# Altium schematic sheet MCU.SchDoc
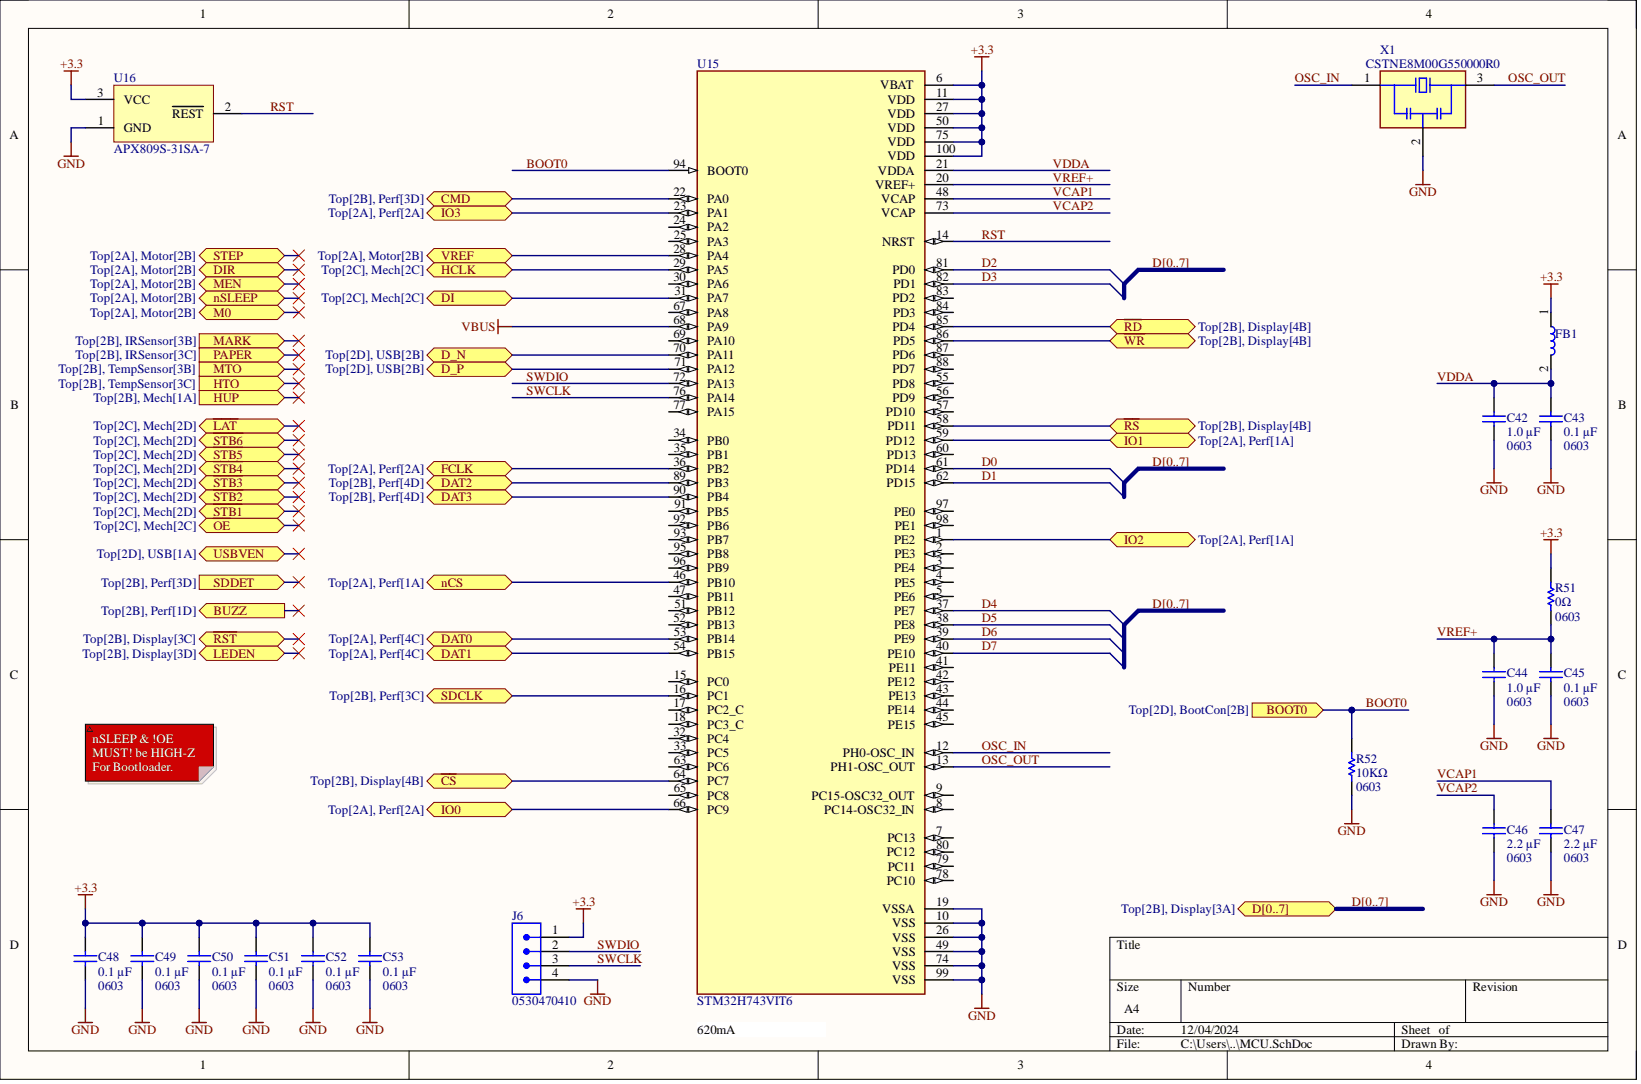
[diagram p0: MCU.SchDoc]

## Parts
C42  value 1.0 µF  [0603]  (hidden comment: GRM188D71H105KE01J)
C43  value 0.1 µF  [0603]  (hidden comment: GRM188R72A104MA35J)
C44  value 1.0 µF  [0603]  (hidden comment: GRM188D71H105KE01J)
C45  value 0.1 µF  [0603]  (hidden comment: GRM188R72A104MA35J)
C46  value 2.2 µF  [0603]  (hidden comment: GRM188R61E225KA12J)
C47  value 2.2 µF  [0603]  (hidden comment: GRM188R61E225KA12J)
C48  value 0.1 µF  [0603]  (hidden comment: GRM188R72A104MA35J)
C49  value 0.1 µF  [0603]  (hidden comment: GRM188R72A104MA35J)
C50  value 0.1 µF  [0603]  (hidden comment: GRM188R72A104MA35J)
C51  value 0.1 µF  [0603]  (hidden comment: GRM188R72A104MA35J)
C52  value 0.1 µF  [0603]  (hidden comment: GRM188R72A104MA35J)
C53  value 0.1 µF  [0603]  (hidden comment: GRM188R72A104MA35J)
FB1  MPZ1608S221ATA00  [0603]  (value not drawn)
J6  0530470410  [4POS]
R51  value 0Ω  [0603]  (hidden comment: RMCF0603ZT0R00)
R52  value 10KΩ  [0603]  (hidden comment: RMCF0603FG10K0)
U15  STM32H743VIT6  [100-LQFP]
U16  APX809S-31SA-7  [SOT-23-3]
X1  CSTNE8M00G550000R0  [3-SMD]

## Ports
BOOT0, BUZZ, CMD, D0, D1, D2, D3, D4, D5, D6, D7, D[0..7], D_N, D_P, DAT0, DAT1, DAT2, DAT3, DI, DIR, FCLK, HCLK, HTO, HUP, IO0, IO1, IO2, IO3, LEDEN, M0, MARK, MEN, MTO, nCS, nSLEEP, PAPER, SDCLK, SDDET, STEP, USBVEN, VREF, ~{CS}, ~{LAT}, ~{OE}, ~{RD}, ~{RST}, ~{RS}, ~{STB1}, ~{STB2}, ~{STB3}, ~{STB4}, ~{STB5}, ~{STB6}, ~{WR}

## Nets
+3.3: C48.2 C49.2 C50.2 C51.2 C52.2 C53.2 FB1.1 J6.1 R51.1 U15.6(VBAT) U15.11(VDD) U15.27(VDD) U15.50(VDD) U15.75(VDD) U15.100(VDD) U16.3
GND: C42.1 C43.1 C44.1 C45.1 C46.1 C47.1 C48.1 C49.1 C50.1 C51.1 C52.1 C53.1 J6.4 R52.1 U15.10(VSS) U15.19(VSSA) U15.26(VSS) U15.49(VSS) U15.74(VSS) U15.99(VSS) U16.1 X1.2
VBUS: U15.68(PA9)
BOOT0: R52.2 U15.94(BOOT0) ; port BOOT0
~{CS}: U15.64(PC7) ; port ~{CS}
CMD: U15.22(PA0) ; port CMD
D0: U15.61(PD14) ; port D[0..7]  [also labelled D]
D1: U15.62(PD15) ; port D[0..7]  [also labelled D]
D2: U15.81(PD0) ; port D[0..7]  [also labelled D]
D3: U15.82(PD1) ; port D[0..7]  [also labelled D]
D4: U15.37(PE7) ; port D[0..7]  [also labelled D]
D5: U15.38(PE8) ; port D[0..7]  [also labelled D]
D6: U15.39(PE9) ; port D[0..7]  [also labelled D]
D7: U15.40(PE10) ; port D[0..7]  [also labelled D]
D_N: U15.70(PA11) ; port D_N
D_P: U15.71(PA12) ; port D_P
DAT0: U15.53(PB14) ; port DAT0
DAT1: U15.54(PB15) ; port DAT1
DAT2: U15.89(PB3) ; port DAT2
DAT3: U15.90(PB4) ; port DAT3
DI: U15.31(PA7) ; port DI
FCLK: U15.36(PB2) ; port FCLK
HCLK: U15.29(PA5) ; port HCLK
IO0: U15.66(PC9) ; port IO0
IO1: U15.59(PD12) ; port IO1
IO2: U15.1(PE2) ; port IO2
IO3: U15.23(PA1) ; port IO3
nCS: U15.46(PB10) ; port nCS
OSC_IN: U15.12(PH0-OSC_IN) X1.1
OSC_OUT: U15.13(PH1-OSC_OUT) X1.3
~{RD}: U15.85(PD4) ; port ~{RD}
~{RS}: U15.58(PD11) ; port ~{RS}
RST: U15.14(NRST) U16.2
SDCLK: U15.16(PC1) ; port SDCLK
SWCLK: J6.3 U15.76(PA14)
SWDIO: J6.2 U15.72(PA13)
VCAP1: C47.2 U15.48(VCAP)
VCAP2: C46.2 U15.73(VCAP)
VDDA: C42.2 C43.2 FB1.2 U15.21(VDDA)
VREF: U15.28(PA4) ; port VREF
VREF+: C44.2 C45.2 R51.2 U15.20(VREF+)
~{WR}: U15.86(PD5) ; port ~{WR}
NetU15_2: U15.2(PE3)
NetU15_3: U15.3(PE4)
NetU15_4: U15.4(PE5)
NetU15_5: U15.5(PE6)
NetU15_7: U15.7(PC13)
NetU15_8: U15.8(PC14-OSC32_IN)
NetU15_9: U15.9(PC15-OSC32_OUT)
NetU15_15: U15.15(PC0)
NetU15_17: U15.17(PC2_C)
NetU15_18: U15.18(PC3_C)
NetU15_24: U15.24(PA2)
NetU15_25: U15.25(PA3)
NetU15_30: U15.30(PA6)
NetU15_32: U15.32(PC4)
NetU15_33: U15.33(PC5)
NetU15_34: U15.34(PB0)
NetU15_35: U15.35(PB1)
NetU15_41: U15.41(PE11)
NetU15_42: U15.42(PE12)
NetU15_43: U15.43(PE13)
NetU15_44: U15.44(PE14)
NetU15_45: U15.45(PE15)
NetU15_47: U15.47(PB11)
NetU15_51: U15.51(PB12)
NetU15_52: U15.52(PB13)
NetU15_55: U15.55(PD8)
NetU15_56: U15.56(PD9)
NetU15_57: U15.57(PD10)
NetU15_60: U15.60(PD13)
NetU15_63: U15.63(PC6)
NetU15_65: U15.65(PC8)
NetU15_67: U15.67(PA8)
NetU15_69: U15.69(PA10)
NetU15_77: U15.77(PA15)
NetU15_78: U15.78(PC10)
NetU15_79: U15.79(PC11)
NetU15_80: U15.80(PC12)
NetU15_83: U15.83(PD2)
NetU15_84: U15.84(PD3)
NetU15_87: U15.87(PD6)
NetU15_88: U15.88(PD7)
NetU15_91: U15.91(PB5)
NetU15_92: U15.92(PB6)
NetU15_93: U15.93(PB7)
NetU15_95: U15.95(PB8)
NetU15_96: U15.96(PB9)
NetU15_97: U15.97(PE0)
NetU15_98: U15.98(PE1)
N00001: ; port MTO
N00002: ; port STEP
N00003: ; port ~{LAT}
N00004: ; port DIR
N00005: ; port nSLEEP
N00006: ; port ~{OE}
N00007: ; port HTO
N00008: ; port MEN
N00009: ; port ~{STB1}
N00010: ; port HUP
N00011: ; port M0
N00012: ; port ~{STB3}
N00013: ; port LEDEN
N00014: ; port ~{STB6}
N00015: ; port MARK
N00016: ; port ~{STB5}
N00017: ; port PAPER
N00018: ; port SDDET
N00019: ; port ~{STB2}
N00020: ; port ~{STB4}
N00021: ; port BUZZ
N00022: ; port ~{RST}
N00023: ; port USBVEN

## Library data of the parts
C42 C44 = GRM188D71H105KE01J: 1 µF ±10% 50V Ceramic Capacitor X7T 0603 (1608 Metric); Case: 0603; Component Kind: Standard; Library Name: Murata Electronics.IntLib; Temperature Coefficient: X7T; Tolerance: ±10%; Voltage: 50V
C43 C45 C48-C53 = GRM188R72A104MA35J: 0.1 µF ±20% 100V Ceramic Capacitor X7R 0603 (1608 Metric); Case: 0603; Component Kind: Standard; Library Name: Murata Electronics.IntLib; Temperature Coefficient: X7R; Tolerance: ±20%; Voltage: 100V
C46 C47 = GRM188R61E225KA12J: 2.2 µF ±10% 25V Ceramic Capacitor X5R 0603 (1608 Metric); Case: 0603; Component Kind: Standard; Library Name: Murata Electronics.IntLib; Temperature Coefficient: X5R; Tolerance: ±10%; Voltage: 25V
FB1 = MPZ1608S221ATA00: 220 Ohms @ 100 MHz 1 Power Line Ferrite Bead 0603 (1608 Metric) 2.2A 50mOhm; Component Kind: Standard; Library Name: TDK Corporation.IntLib
J6 = 0530470410: Connector Header Through Hole 4 position 0.049" (1.25mm); Component Kind: Standard; Library Name: Molex.IntLib
R51 = RMCF0603ZT0R00: 0 Ohms Jumper Chip Resistor 0603 (1608 Metric) Automotive AEC-Q200 Thick Film; Power: 0; Case: 0603; Component Kind: Standard; Tolerance: 0; Library Name: Stackpole Electronics Inc.IntLib
R52 = RMCF0603FG10K0: 10 kOhms ±1% 0.1W, 1/10W Chip Resistor 0603 (1608 Metric) Automotive AEC-Q200 Thick Film; Case: 0603; Component Kind: Standard; Power: 0.1W; Tolerance: 1%; Library Name: Stackpole Electronics Inc.IntLib
U15 = STM32H743VIT6: ARM® Cortex®-M7 STM32H7 Microcontroller IC 32-Bit Single-Core 480MHz 2MB (2M x 8) FLASH 100-LQFP (14x14); Component Kind: Standard; Library Name: STMicroelectronics.IntLib
U16 = APX809S-31SA-7: Supervisor Push-Pull, Totem Pole 1 Channel SOT-23-3; Component Kind: Standard; Library Name: Diodes Incorporated.IntLib
X1 = CSTNE8M00G550000R0: 8 MHz Ceramic Resonator Built in Capacitor 33 pF ±0.2% 40 Ohms -40°C ~ 85°C Surface Mount; Component Kind: Standard; Library Name: Murata Electronics.IntLib
